# Altium schematic sheet [05] - PMOD.SchDoc("[05] - PMOD")
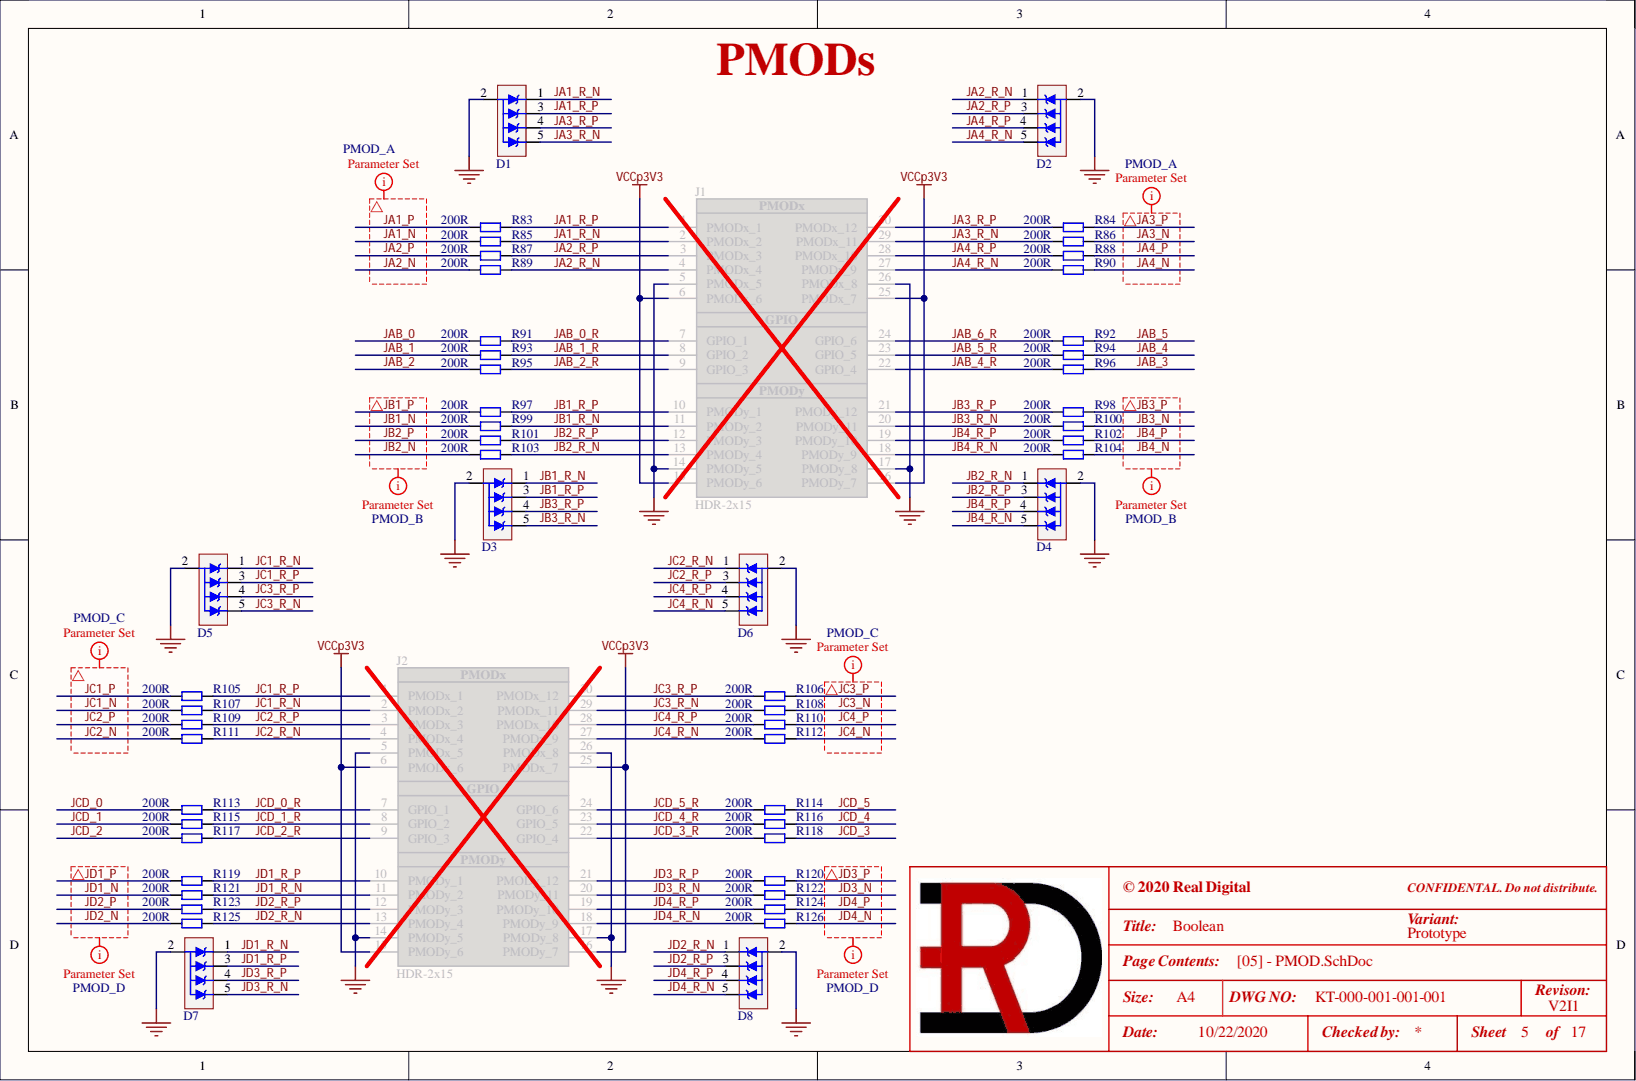
[diagram p0: [05] - PMOD.SchDoc]

## Parts
D1  NSQA6V8AW5T2G  [SOT-323-5]  (value not drawn)
D2  NSQA6V8AW5T2G  [SOT-323-5]  (value not drawn)
D3  NSQA6V8AW5T2G  [SOT-323-5]  (value not drawn)
D4  NSQA6V8AW5T2G  [SOT-323-5]  (value not drawn)
D5  NSQA6V8AW5T2G  [SOT-323-5]  (value not drawn)
D6  NSQA6V8AW5T2G  [SOT-323-5]  (value not drawn)
D7  NSQA6V8AW5T2G  [SOT-323-5]  (value not drawn)
D8  NSQA6V8AW5T2G  [SOT-323-5]  (value not drawn)
J1  HDR-2x15  [PMOD_X2_PLUS_6_OFF]
J2  HDR-2x15  [PMOD_X2_PLUS_6_OFF]
R83  200R  [R0402]
R84  200R  [R0402]
R85  200R  [R0402]
R86  200R  [R0402]
R87  200R  [R0402]
R88  200R  [R0402]
R89  200R  [R0402]
R90  200R  [R0402]
R91  200R  [R0402]
R92  200R  [R0402]
R93  200R  [R0402]
R94  200R  [R0402]
R95  200R  [R0402]
R96  200R  [R0402]
R97  200R  [R0402]
R98  200R  [R0402]
R99  200R  [R0402]
R100  200R  [R0402]
R101  200R  [R0402]
R102  200R  [R0402]
R103  200R  [R0402]
R104  200R  [R0402]
R105  200R  [R0402]
R106  200R  [R0402]
R107  200R  [R0402]
R108  200R  [R0402]
R109  200R  [R0402]
R110  200R  [R0402]
R111  200R  [R0402]
R112  200R  [R0402]
R113  200R  [R0402]
R114  200R  [R0402]
R115  200R  [R0402]
R116  200R  [R0402]
R117  200R  [R0402]
R118  200R  [R0402]
R119  200R  [R0402]
R120  200R  [R0402]
R121  200R  [R0402]
R122  200R  [R0402]
R123  200R  [R0402]
R124  200R  [R0402]
R125  200R  [R0402]
R126  200R  [R0402]

## Nets
GND: D1.2 D2.2 D3.2 D4.2 D5.2 D6.2 D7.2 D8.2 J1.5(PMODx_5) J1.14(PMODy_5) J1.17(PMODy_8) J1.26(PMODx_8) J2.5(PMODx_5) J2.14(PMODy_5) J2.17(PMODy_8) J2.26(PMODx_8)
VCCp3V3: J1.6(PMODx_6) J1.15(PMODy_6) J1.16(PMODy_7) J1.25(PMODx_7) J2.6(PMODx_6) J2.15(PMODy_6) J2.16(PMODy_7) J2.25(PMODx_7)
JA1_N: R85.1
JA1_P: R83.1
JA1_R_N: D1.1 J1.2(PMODx_2) R85.2
JA1_R_P: D1.3 J1.1(PMODx_1) R83.2
JA2_N: R89.1
JA2_P: R87.1
JA2_R_N: D2.1 J1.4(PMODx_4) R89.2
JA2_R_P: D2.3 J1.3(PMODx_3) R87.2
JA3_N: R86.2
JA3_P: R84.2
JA3_R_N: D1.5 J1.29(PMODx_11) R86.1
JA3_R_P: D1.4 J1.30(PMODx_12) R84.1
JA4_N: R90.2
JA4_P: R88.2
JA4_R_N: D2.5 J1.27(PMODx_9) R90.1
JA4_R_P: D2.4 J1.28(PMODx_10) R88.1
JAB_0: R91.1
JAB_0_R: J1.7(GPIO_1) R91.2
JAB_1: R93.1
JAB_1_R: J1.8(GPIO_2) R93.2
JAB_2: R95.1
JAB_2_R: J1.9(GPIO_3) R95.2
JAB_3: R96.2
JAB_4: R94.2
JAB_4_R: J1.22(GPIO_4) R96.1
JAB_5: R92.2
JAB_5_R: J1.23(GPIO_5) R94.1
JAB_6_R: J1.24(GPIO_6) R92.1
JB1_N: R99.1
JB1_P: R97.1
JB1_R_N: D3.1 J1.11(PMODy_2) R99.2
JB1_R_P: D3.3 J1.10(PMODy_1) R97.2
JB2_N: R103.1
JB2_P: R101.1
JB2_R_N: D4.1 J1.13(PMODy_4) R103.2
JB2_R_P: D4.3 J1.12(PMODy_3) R101.2
JB3_N: R100.2
JB3_P: R98.2
JB3_R_N: D3.5 J1.20(PMODy_11) R100.1
JB3_R_P: D3.4 J1.21(PMODy_12) R98.1
JB4_N: R104.2
JB4_P: R102.2
JB4_R_N: D4.5 J1.18(PMODy_9) R104.1
JB4_R_P: D4.4 J1.19(PMODy_10) R102.1
JC1_N: R107.1
JC1_P: R105.1
JC1_R_N: D5.1 J2.2(PMODx_2) R107.2
JC1_R_P: D5.3 J2.1(PMODx_1) R105.2
JC2_N: R111.1
JC2_P: R109.1
JC2_R_N: D6.1 J2.4(PMODx_4) R111.2
JC2_R_P: D6.3 J2.3(PMODx_3) R109.2
JC3_N: R108.2
JC3_P: R106.2
JC3_R_N: D5.5 J2.29(PMODx_11) R108.1
JC3_R_P: D5.4 J2.30(PMODx_12) R106.1
JC4_N: R112.2
JC4_P: R110.2
JC4_R_N: D6.5 J2.27(PMODx_9) R112.1
JC4_R_P: D6.4 J2.28(PMODx_10) R110.1
JCD_0: R113.1
JCD_0_R: J2.7(GPIO_1) R113.2
JCD_1: R115.1
JCD_1_R: J2.8(GPIO_2) R115.2
JCD_2: R117.1
JCD_2_R: J2.9(GPIO_3) R117.2
JCD_3: R118.2
JCD_3_R: J2.22(GPIO_4) R118.1
JCD_4: R116.2
JCD_4_R: J2.23(GPIO_5) R116.1
JCD_5: R114.2
JCD_5_R: J2.24(GPIO_6) R114.1
JD1_N: R121.1
JD1_P: R119.1
JD1_R_N: D7.1 J2.11(PMODy_2) R121.2
JD1_R_P: D7.3 J2.10(PMODy_1) R119.2
JD2_N: R125.1
JD2_P: R123.1
JD2_R_N: D8.1 J2.13(PMODy_4) R125.2
JD2_R_P: D8.3 J2.12(PMODy_3) R123.2
JD3_N: R122.2
JD3_P: R120.2
JD3_R_N: D7.5 J2.20(PMODy_11) R122.1
JD3_R_P: D7.4 J2.21(PMODy_12) R120.1
JD4_N: R126.2
JD4_P: R124.2
JD4_R_N: D8.5 J2.18(PMODy_9) R126.1
JD4_R_P: D8.4 J2.19(PMODy_10) R124.1

## Library data of the parts
D1-D8 = NSQA6V8: TVS DIODE 5V 13V SC88A; Manufacturer 1: ON Semiconductor; .Checked_PCB: AC; .Creator: RV; C P/N: 121-0019; LoadStatus: Load; Category: Diodes; Component Kind: Standard; Library Name: KT-RealDigital.IntLib; Manufacturer 1 Part Number: NSQA6V8AW5T2G; .Checked_SCH: AC
J1 J2 = PMODx2_Plus_6: Header 2.54mm, 2x15; .Creator: RV; LoadStatus: Load; Category: Connectors; Component Kind: Standard; Library Name: KT-RealDigital.IntLib
R83-R126 = RC0402JR-07200RL: RES SMD 200 OHM 5% 1/16W 0402; Manufacturer 1: Yageo; .Checked_PCB: AC; .Creator: RV; C P/N: 101-0045; LoadStatus: Load; Category: Resistors; Component Kind: Standard; Library Name: KT-RealDigital.IntLib; Manufacturer 1 Part Number: RC0402JR-07200RL; Tolerance: 5%; .Checked_SCH: AC
